# Altium schematic sheet 1_BLE.SchDoc(1_BLE)
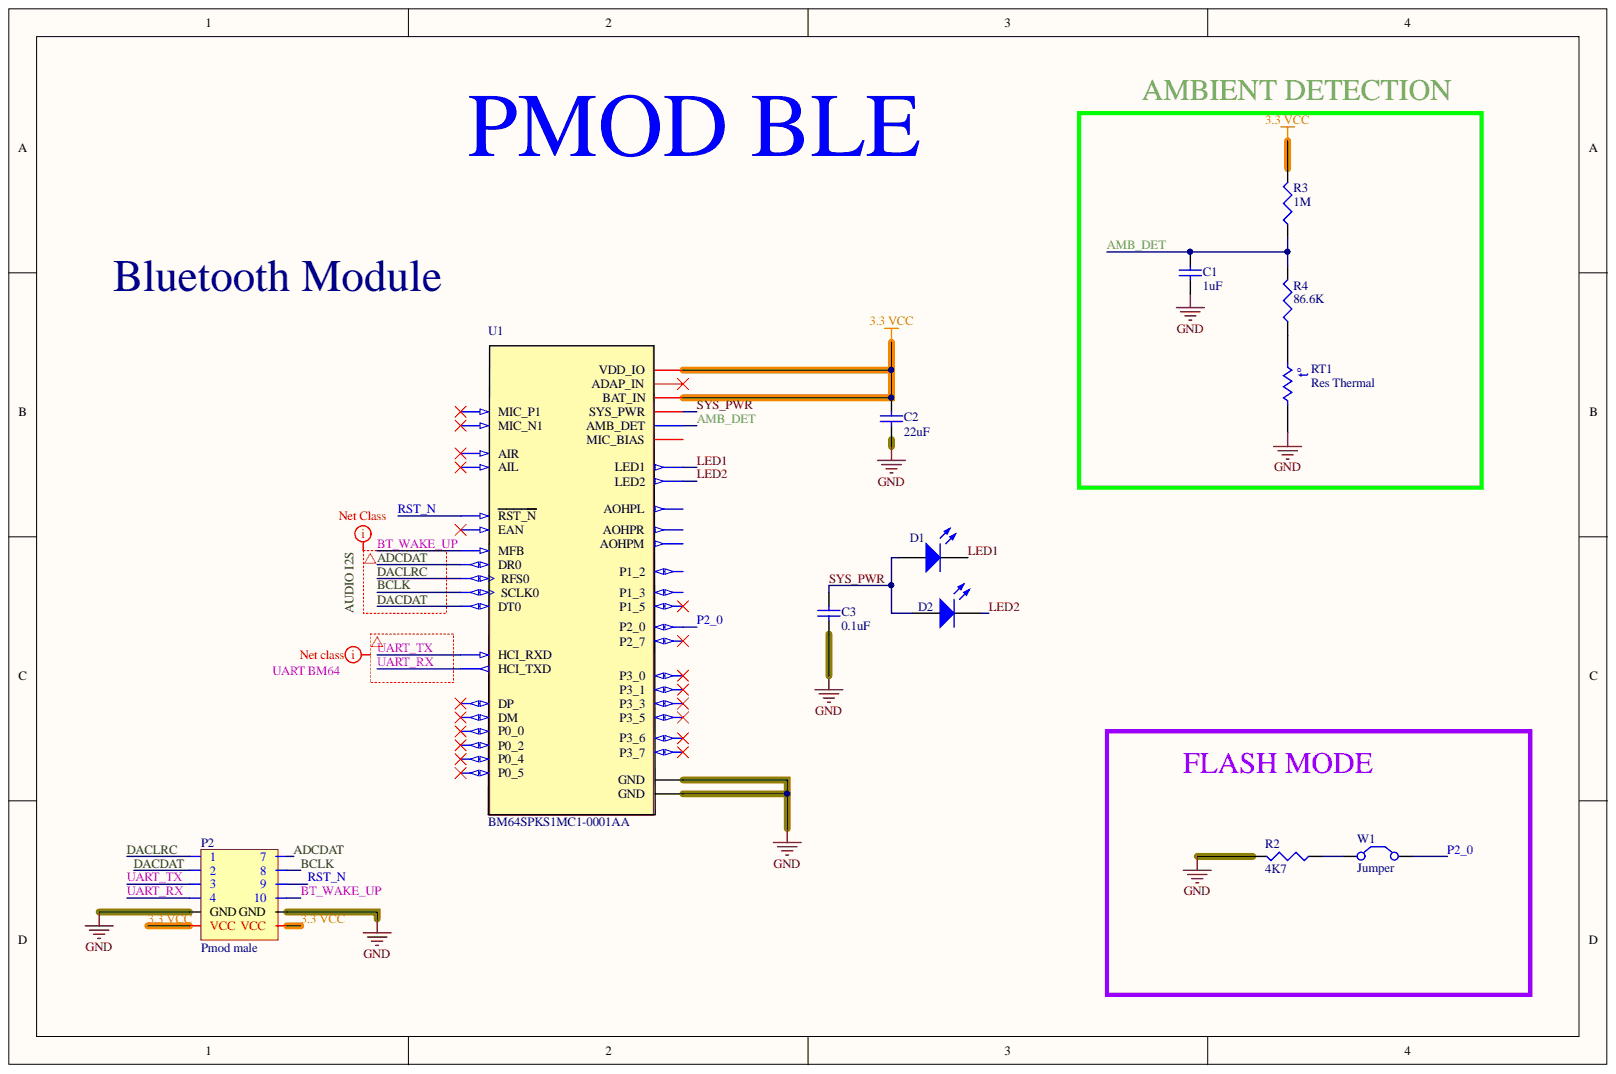
[diagram p0: 1_BLE.SchDoc]

## Parts
C1  1uF  [Cap0805]
C2  value 22uF  [C1210]  (hidden comment: Cap Semi)
C3  0.1uF  [Cap0805]
D1  LED1  [Diode1206]
D2  LED1  [Diode1206]  (value not drawn)
P2  Pmod male  [901220766]
R2  value 4K7  [Resistor 0805]  (hidden comment: Res3)
R3  value 1M  [Resistor 0805]  (hidden comment: Res3)
R4  value 86.6K  [Resistor 0805]  (hidden comment: Res3)
RT1  Res Thermal  [6-0805_N]
U1  BM64SPKS1MC1-0001AA  [*MODULE_BM64SPKS1MC1-0001AA]
W1  Jumper  [Shunt jumper]

## Nets
GND: C1.1 C2.1 C3.2 P2.5(GND) P2.11(GND) R2.2 RT1.2 U1.14(GND) U1.43(GND)
3.3 VCC: C2.2 P2.6(VCC) P2.12(VCC) R3.2 U1.21(VDD_IO) U1.22(BAT_IN)
ADCDAT: P2.7 U1.1(DR0)
AMB_DET: C1.2 R3.1 R4.2 U1.25(AMB_DET)
BCLK: P2.8 U1.3(SCLK0)
BT_WAKE_UP: P2.10 U1.26(MFB)
DACDAT: P2.2 U1.4(DT0)
DACLRC: P2.1 U1.2(RFS0)
LED1: D1.2 U1.28(LED1)
LED2: D2.2 U1.27(LED2)
P2_0: U1.41(P2_0) W1.2
RST_N: P2.9 U1.13(RST_N)
SYS_PWR: C3.1 D1.1 D2.1 U1.24(SYS_PWR)
UART_RX: P2.4 U1.20(HCI_TXD)
UART_TX: P2.3 U1.19(HCI_RXD)
NetR2_1: R2.1 W1.1
NetR4_1: R4.1 RT1.1
N00011:
N00012:

Not connected: U1 5(AOHPR) 6(AOHPM) 7(AOHPL) 8(MIC_N1) 9(MIC_P1) 10(MIC_BIAS) 11(AIR) 12(AIL) 15(P1_2) 16(P1_3) 17(P0_4) 18(P1_5) 23(ADAP_IN) 29(P3_7) 30(P3_5) 31(P0_0) 32(EAN) 33(DM) 34(DP) 35(P0_5) 36(P3_0) 37(P3_1) 38(P3_3) 39(P3_6) 40(P0_2) 42(P2_7)

## Library data of the parts
C1 C3 = Cap: capacitor; ASiD Part Number: C-AS; Volte: 25v; Component Kind: Standard; ClassName: OutBuck; Library Name: navid2015.IntLib
  C1: Value: 1uF
  C3: Value: 0.1uF
C2 = Cap Semi: Capacitor (Semiconductor SIM Model); Simulation: CAP; Signal Integrity: Cap Semi; Published: 8-Jun-2000; PackageReference: C1206; PackageDescription: Chip Capacitor; Library Name: Miscellaneous Devices.IntLib; LatestRevisionNote: IPC-7351 Footprint Added.; LatestRevisionDate: 29-May-2009; Component Kind: Standard; Publisher: Altium Limited
D1 D2 = LED1: Typical RED GaAs LED; Simulation: LED1; Published: 8-Jun-2000; PackageReference: LED-1; PackageDescription: LED; 2 Leads; Library Name: Miscellaneous Devices.IntLib; LatestRevisionNote: Mech Layer 1 removed.; LatestRevisionDate: 08-Jul-2005; Digi-KeyReference: 732-13395-1-ND; Component Kind: Standard; Publisher: Altium Limited
P2 = Pmod male: p mod male 6 position 2 rows; Library Name: Schlib1.SchLib; Component Kind: Standard
R2-R4 = Res3: Resistor; Simulation: RESISTOR; Signal Integrity: Res; Published: 8-Jun-2000; PackageReference: J1-0603; PackageDescription: Chip Resistor; Library Name: Miscellaneous Devices.IntLib; LatestRevisionNote: IPC-7351 Footprint Added.; LatestRevisionDate: 29-May-2009; Component Kind: Standard; Publisher: Altium Limited
RT1 = Res Thermal: Thermistor; R at 25: 100k; Published: 24-Mar-1999; PackageVersion: Mar-2003; PackageReference: 6-0805; PackageDescription: Chip Resistor 0805 Case Size; Library Name: Miscellaneous Devices.IntLib; LatestRevisionNote: IPC-7351 Footprint Added.; LatestRevisionDate: 29-May-2009; Digi-KeyReference: BC2562CT-ND; Component Kind: Standard; Code_IPC: RESC2012; Publisher: Altium Limited
U1 = BM64SPKS1MC1-0001AA: Bluetooth Bluetooth v5.0 Transceiver Module 2.4GHz Integrated, Trace Surface Mount; MF: Microchip; SnapEDA_Link: https://www.snapeda.com/parts/BM64SPKS1MC1-0001AA/Microchip/view-part/613145/?ref=snap; Price: None; Package: Module Microchip; MP: BM64SPKS1MC1-0001AA; Library Name: Schlib1.SchLib; Component Kind: Standard; Availability: Unavailable
W1 = Jumper: Jumper Wire; Published: 24-Mar-1999; PackageReference: RAD-0.2; PackageDescription: Radial Cap, Thru-Hole; 2 Leads; 0.2 in Pin Spacing; Library Name: Miscellaneous Devices.IntLib; LatestRevisionNote: Re-released for DXP Platform.; LatestRevisionDate: 17-Jul-2002; Digi-KeyReferencer: S9000-ND; Component Kind: Standard; Publisher: Altium Limited
